# Altium schematic sheet 14_I2C_Sensor_OnBoard.SchDoc(14_I2C_Sensor_OnBoard)
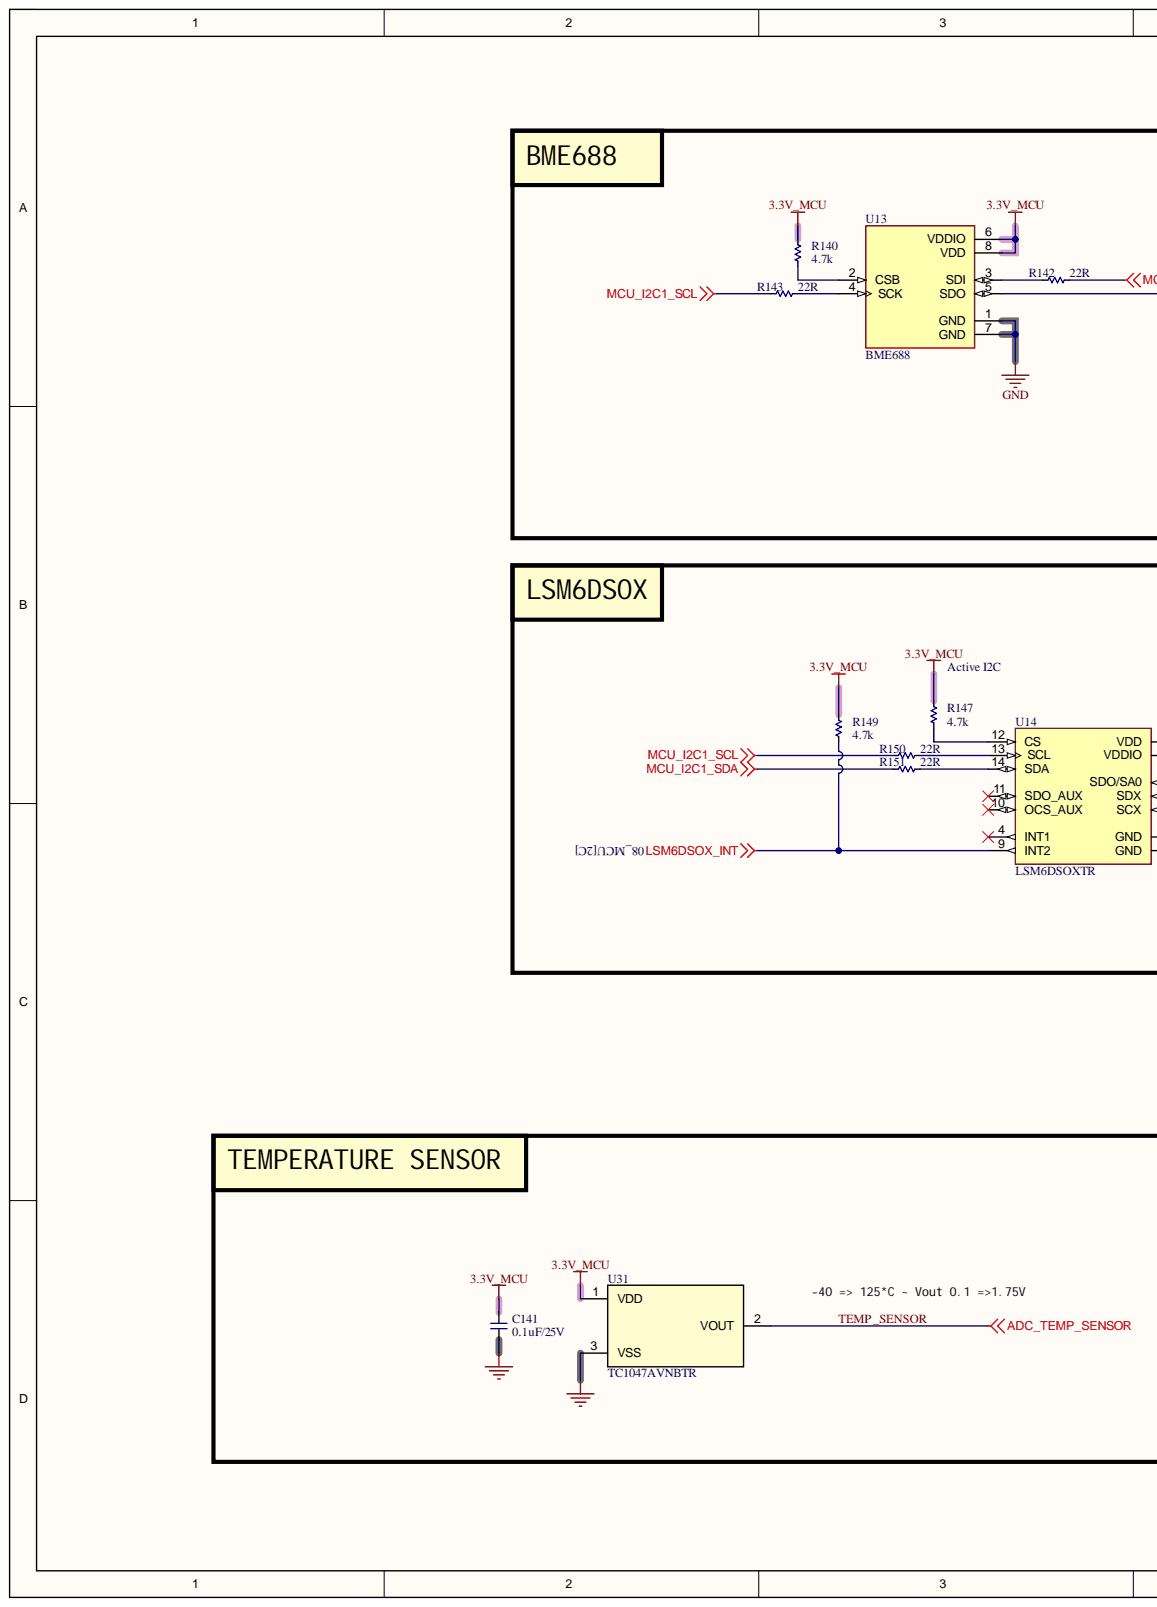
[diagram p0: 14_I2C_Sensor_OnBoard.SchDoc, left]
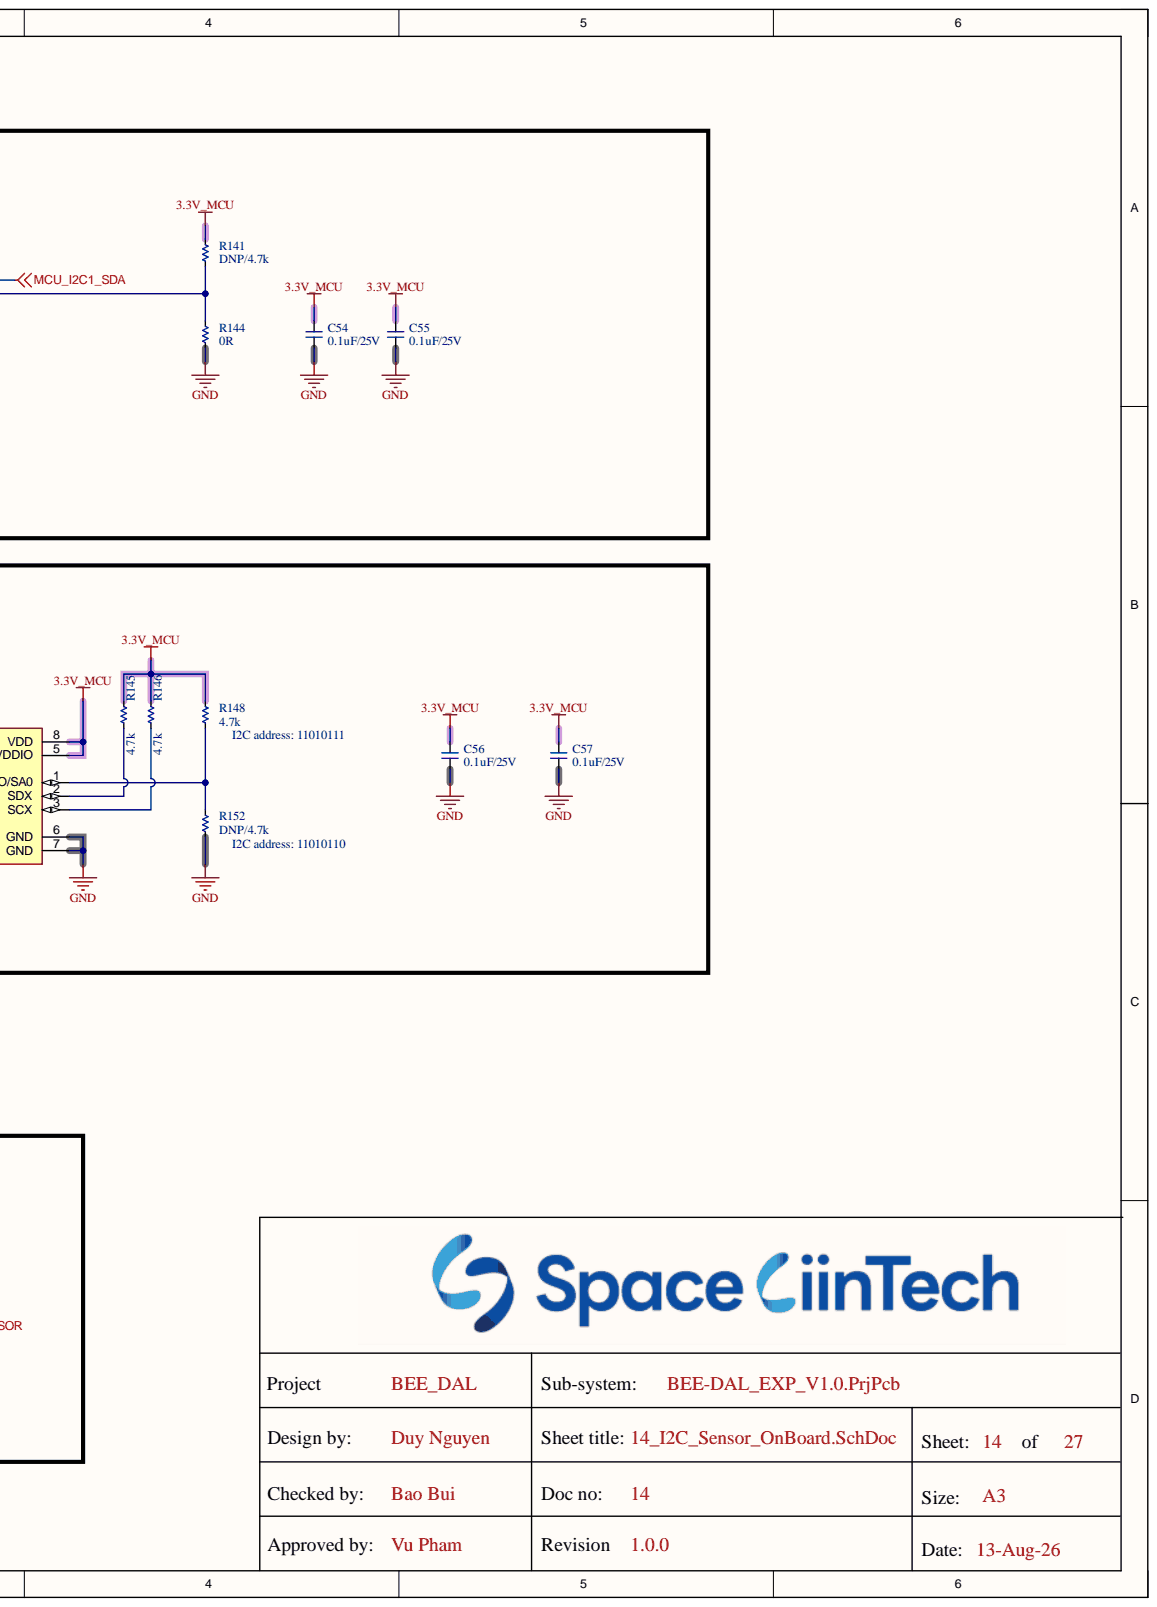
[diagram p1: 14_I2C_Sensor_OnBoard.SchDoc, right]

## Parts
C54  0.1uF/25V  [CAP_C_1005X60]
C55  0.1uF/25V  [CAP_C_1005X60]
C56  0.1uF/25V  [CAP_C_1005X60]
C57  0.1uF/25V  [CAP_C_1005X60]
C141  0.1uF/25V  [CAP_C_1005X60]
R140  4.7k  [RES_C_1005X40]
R141  DNP/4.7k  [RES_C_1005X40]
R142  22R  [RES_C_1005X40]
R143  22R  [RES_C_1005X40]
R144  0R  [RES_C_1005X40]
R145  4.7k  [RES_C_1005X40]
R146  4.7k  [RES_C_1005X40]
R147  4.7k  [RES_C_1005X40]
R148  4.7k  [RES_C_1005X40]
R149  4.7k  [RES_C_1005X40]
R150  22R  [RES_C_1005X40]
R151  22R  [RES_C_1005X40]
R152  DNP/4.7k  [RES_C_1005X40]
U13  BME688  [IC_BME688]
U14  LSM6DSOXTR  [PQFN50P250X300X86-14N]
U31  TC1047AVNBTR  [SOT95P240X120-3N]

## Nets
3.3V_MCU: C54.2 C55.2 C56.2 C57.2 C141.2 R140.1 R141.1 R145.1 R146.1 R147.1 R148.1 R149.1 U13.6(VDDIO) U13.8(VDD) U14.5(VDDIO) U14.8(VDD) U31.1
GND: C54.1 C55.1 C56.1 C57.1 C141.1 R144.2 R152.2 U13.1(GND) U13.7(GND) U14.6(GND) U14.7(GND) U31.3
LSM6DSOX_INT: R149.2 U14.9(INT2)
MCU_I2C1_SCL: R143.1 R150.1
MCU_I2C1_SDA: R142.2 R151.1
TEMP _SENSOR: U31.2
NetR140_2: R140.2 U13.2(CSB)
NetR141_2: R141.2 R144.1 U13.5(SDO)
NetR142_1: R142.1 U13.3(SDI)
NetR143_2: R143.2 U13.4(SCK)
NetR145_2: R145.2 U14.2(SDX)
NetR146_2: R146.2 U14.3(SCX)
NetR147_2: R147.2 U14.12(CS)
NetR148_2: R148.2 R152.1 U14.1(SDO/SA0)
NetR150_2: R150.2 U14.13(SCL)
NetR151_2: R151.2 U14.14(SDA)

Not connected: U14 4(INT1) 10(OCS_AUX) 11(SDO_AUX)

## Library data of the parts
C54-C57 C141 = _CAP_C_2: CAP; Component Kind: Standard; Library Name: LABLIB.SchLib
R140-R152 = _RES_B_2: RES; Component Kind: Standard; Library Name: LABLIB.SchLib
U13 = BME688: Gas sensor measuring relative humidity, barometric pressure, ambient temperature and gas (VOC); Availability: Unavailable; Component Kind: Standard; MP: BME688; Package: None; Price: None; Library Name: LABLIB.SchLib
U14 = LSM6DSOXTR: Accelerometer, Gyroscope, 6 Axis Sensor I2C, SPI Output; MF: STMicroelectronics; Check_prices: https://www.snapeda.com/parts/LSM6DSOXTR/STMicroelectronics/view-part/?ref=eda; Component Kind: Standard; MP: LSM6DSOXTR; Package: VFLGA-14 STMicroelectronics; SnapEDA_Link: https://www.snapeda.com/parts/LSM6DSOXTR/STMicroelectronics/view-part/?ref=snap; Library Name: LABLIB.SchLib
U31 = IC_SENSOR_TC1047AVNBTR: Temperature Sensor Analog, Local -40°C ~ 125°C 10mV/°C SOT-23-3; Component Kind: Standard; Library Name: LABLIB.SchLib
